# Altium schematic sheet JWB-001_Charger.SchDoc
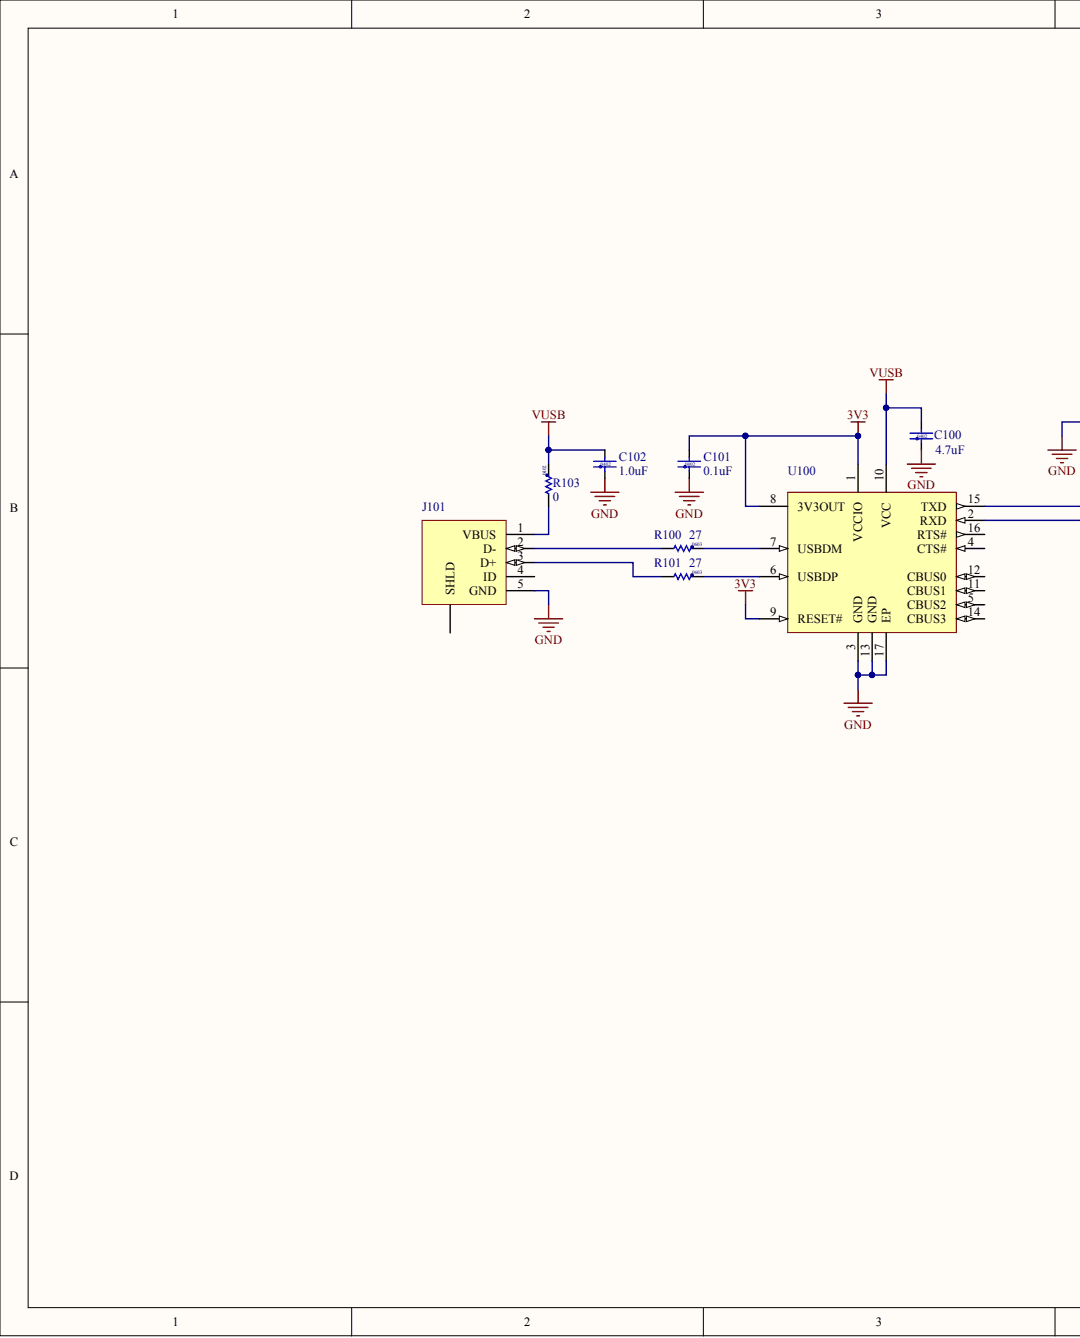
[diagram p0: JWB-001_Charger.SchDoc, left]
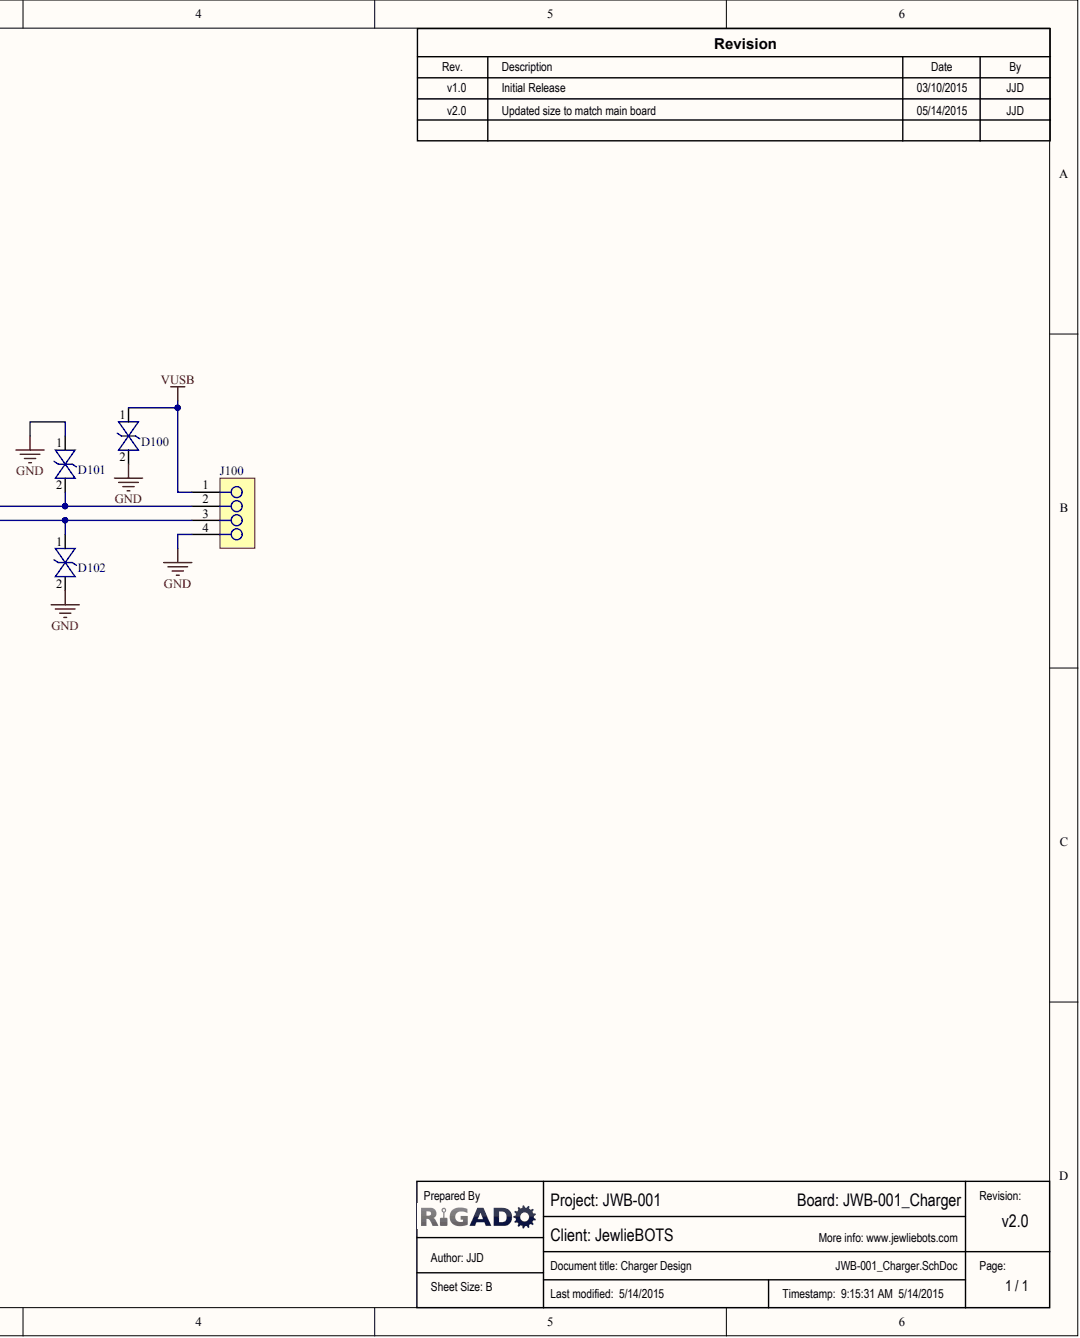
[diagram p1: JWB-001_Charger.SchDoc, right]

## Parts
C100  value 4.7uF  Size: 0402  [Cap 0402 HD]  (hidden comment: Cap 0402 4.7uF 10V4.7uF)
C101  value 0.1uF  Size: 0402  [Cap 0402 HD]  (hidden comment: Cap 0402 0.1uF 10V0.1uF)
C102  value 1.0uF  Size: 0402  [Cap 0402 HD]  (hidden comment: Cap 0402 1.0uF 10V1.0uF)
D100  SESD0402P1BN-0450-0906V  [CAP 0402 HD]  (value not drawn)
D101  SESD0402P1BN-0450-0906V  [CAP 0402 HD]  (value not drawn)
D102  SESD0402P1BN-0450-0906V  [CAP 0402 HD]  (value not drawn)
J100  854-22-004-30-001101  [HDR.050P-4Pin-SMT-R]  (value not drawn)
J101  USB_Micro_B_W-Tabs  [USB_Micro_RA_W-TABS]  (value not drawn)
R100  value 27  Size: 0603  [RES 0603 HD]  (hidden comment: Res 0603 27R 5%27)
R101  value 27  Size: 0603  [RES 0603 HD]  (hidden comment: Res 0603 27R 5%27)
R103  value 0  Size: 0402  [RES 0402]  (hidden comment: Res 0402 0R Jumper0)
U100  FT230XQ  [QFN0.65P4x4-16Pin_W-Pad]  (value not drawn)

## Nets
3V3: C101.1 U100.1(VCCIO) U100.8(3V3OUT) U100.9(RESET#)
GND: C100.2 C101.2 C102.2 D100.2 D101.1 D102.2 J100.4 J101.5(GND) U100.3(GND) U100.13(GND) U100.17(EP)
VUSB: C100.1 C102.1 D100.1 J100.1 R103.2 U100.10(VCC)
NetD101_2: D101.2 J100.2 U100.15(TXD)
NetD102_1: D102.1 J100.3 U100.2(RXD)
NetJ101_1: J101.1(VBUS) R103.1
NetJ101_2: J101.2(D-) R100.1
NetJ101_3: J101.3(D+) R101.1
NetR100_2: R100.2 U100.7(USBDM)
NetR101_2: R101.2 U100.6(USBDP)

Not connected: J101 4(ID) 6(SHLD); U100 4(CTS#) 5(CBUS2) 11(CBUS1) 12(CBUS0) 14(CBUS3) 16(RTS#)

## Library data of the parts
C100 = Cap 0402 4.7uF 10V: Cap, Ceramic 4.7uF 10V 20% X5R 0402; Manufacturer: Samsung; Manufacturer 2 P/N: C1005X5R1A475M050BC; Manufacturer P/N: CL05A475MP5NRNC; Added By: Jeremy D; Database Table Name: Capacitors; Vendor: Digikey; Vendor P/N: 1276-1482-1-ND; Added Date: 2/1/2015; Checked Date: 2/5/2015; Component Kind: Standard; Height: 0.55m; Manufacturer 2: TDK; Part Number: Cap 0402 4.7uF 10V; Signal Integrity: Cap Semi; Simulation: CAP; Temp Coefficient: X5R; Tolerance: 20%; Voltage: 10V; Checked By: Paul B; Library Name: LOCAL_Rigado_AltiumLib.DbLib
C101 = Cap 0402 0.1uF 10V: Cap, Ceramic 0.1uF 10V 10% X5R 0402; Manufacturer: Murata; Manufacturer 2 P/N: CC0402KRX5R6BB104; Manufacturer P/N: GRM155R61A104KA01J; Added By: Jeremy D; Database Table Name: Capacitors; Added Date: 4/7/2015; Checked Date: 4/10/2015; Component Kind: Standard; Height: 0.6mm; Manufacturer 2: Yageo; Part Number: Cap 0402 0.1uF 10V; Signal Integrity: Cap Semi; Simulation: CAP; Supplier 1: Digi-key; Supplier Part Number 1: 490-6297-1-ND; Temp Coefficient: X5R; Tolerance: 10%; Voltage: 10V; Checked By: Joe K; Library Name: Rigado_AltiumLib.DbLib
C102 = Cap 0402 1.0uF 10V: Cap, Ceramic 1.0uF 10V 10% X5R 0402; Manufacturer: Murata; Manufacturer 2 P/N: C1005X5R0J105K050BB; Manufacturer P/N: GRM155R61A105KE15D; Added By: Jeremy D; Database Table Name: Capacitors; Added Date: 2/1/2015; Checked Date: 2/5/2015; Component Kind: Standard; Height: 0.55mm; Manufacturer 2: TDK; Part Number: Cap 0402 1.0uF 10V; Signal Integrity: Cap Semi; Simulation: CAP; Supplier 1: Digi-key; Supplier Part Number 1: 490-3890-1-ND; Temp Coefficient: X5R; Tolerance: 10%; Voltage: 10V; Checked By: Paul B; Library Name: Rigado_AltiumLib.DbLib
D100-D102 = SESD0402P1BN-0450-090: ESD TVS Diode, 16kV air, 0402; Manufacturer: TE Connectivity; Added By: Jeremy D; Database Table Name: Diodes; Vendor: Digikey; Vendor P/N: SESD0402P1BN-0450-090CT-ND; Voltage Rated: 6V; Added Date: 2/1/2015; Checked Date: 2/5/2015; Component Kind: Standard; Height: 0.6mm; Manufacturer P/N: SESD0402P1BN-0450-090; Package: 0402; Part Number: SESD0402P1BN-0450-090; Size: 1.1mm x 0.5mm; Type: TVS; Checked By: Paul B; Library Name: LOCAL_Rigado_AltiumLib.DbLib
J100 = 854-22-004-30-001101: Spring Loaded Header 0.05" Pitch, 4Pin, 4x1, 4.98mm - 6.4mm Height; Manufacturer: Mill-Max; Added By: Jeremy D; Contacts: 4; Database Table Name: Connectors; Keyed: No; Rows: 1; Vendor: Mouser; Vendor P/N: 575-854-22-004-30; Added Date: 2/23/2015; Checked Date: 2/27/2015; Component Kind: Standard; Height: 6.4mm; Locking: No; Manufacturer P/N: 854-22-004-30-001101; Part Number: 854-22-004-30-001101; Pin Pitch: 0.05"; Size: 5.47mm x 2.21mm; Checked By: Cam N; Library Name: LOCAL_Rigado_AltiumLib.DbLib
J101 = USB_Micro_B_W-Tabs: USB Micro B Receptacle, Right Angle, SMT with 4 TH Tabs; Manufacturer: FCI; Manufacturer 2 P/N: ZX62D-B-5P8; Manufacturer P/N: 10118194-0001LF; Added By: Jeremy D; Contacts: 6; Database Table Name: Connectors; Keyed: Yes; Locking: No; Pin Pitch: 0.65mm; Rows: 1; Vendor: Digikey; Vendor P/N: 609-4618-1-ND; Added Date: 3/5/2015; Component Kind: Standard; Height: 2.8mm; Manufacturer 2: Hirose; Part Number: USB_Micro_B_W-Tabs; Size: 8mm x 5mm; Library Name: LOCAL_Rigado_AltiumLib.DbLib
R100 R101 = Res 0603 27R 5%: Resistor 27 ohm 5%, 1/10W, 0603; Manufacturer: Rohm; Manufacturer 2 P/N: RC1608J270CS; Manufacturer P/N: MCR03ERTJ270; Added By: Jeremy D; Database Table Name: Resistors; Vendor: Digikey; Vendor P/N: RHM27CGCT-ND; Added Date: 3/5/2015; Component Kind: Standard; Height: .55mm; Manufacturer 2: Samsung; Part Number: Res 0603 27R 5%; Power: 1/10W; Signal Integrity: Res; Simulation: RESISTOR; Tolerance: 5%; Library Name: LOCAL_Rigado_AltiumLib.DbLib
R103 = Res 0402 0R Jumper: Resistor 0 ohm 1/16W 0402; Manufacturer: Samsung; Manufacturer 2 P/N: MCR01MRTJ000; Manufacturer P/N: RC1005J000CS; Added By: Jeremy D; Database Table Name: Resistors; Added Date: 4/12/2015; Component Kind: Standard; Height: 0.4mm; Manufacturer 2: Rohm; Part Number: Res 0402 0R Jumper; Power: 1/16W; Signal Integrity: Res; Simulation: RESISTOR; Supplier 1: Digi-key; Supplier Part Number 1: 1276-3480-1-ND; Library Name: Rigado_AltiumLib.DbLib
U100 = FT230XQ: USB to UART converter IC, Single channel with flow control, QFN-16; Manufacturer: FTDI; Manufacturer P/N: FT230XQ-R; 1k Price: 1.46; Added By: Jeremy D; Database Table Name: Interface; Vendor: Digikey; Vendor P/N: 768-1130-1-ND; Voltage-Rated: 5.5V; Added Date: 3/5/2015; Component Kind: Standard; Controller Interface: UART; Features: USB to UART with flow control; Height: .8mm; MFG Footprint: QFN-16; Packaging: Tape & Reel; Part Number: FT230XQ; Size: 4.1mm x 4.1mm; Library Name: LOCAL_Rigado_AltiumLib.DbLib
